# Altium schematic sheet DVC1124.SchDoc
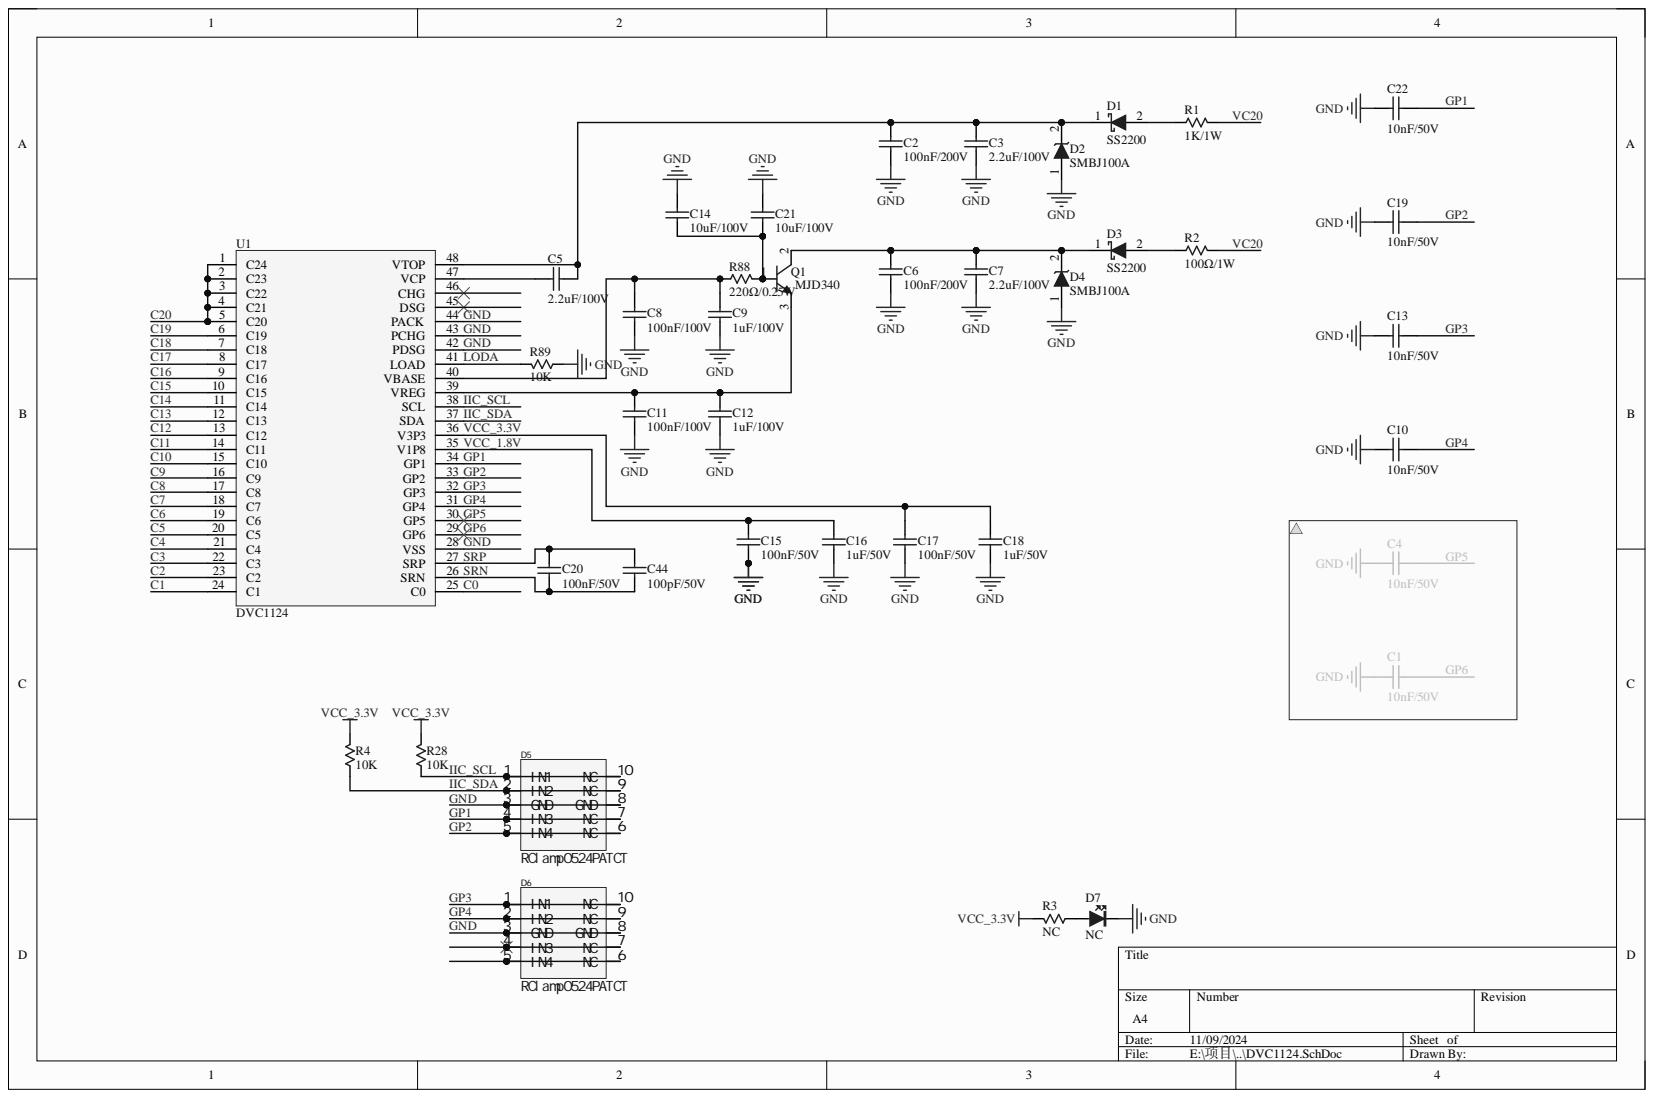
[diagram p0: DVC1124.SchDoc]

## Parts
C2  100nF/200V  [0805C]
C3  2.2uF/100V  [0805C]
C5  2.2uF/100V  [0805C]
C6  100nF/200V  [0805C]
C7  2.2uF/100V  [0805C]
C8  100nF/100V  [0805C]
C9  1uF/100V  [0805C]
C10  10nF/50V  [0603_C]
C11  100nF/100V  [0805C]
C12  1uF/100V  [0805C]
C13  10nF/50V  [0603_C]
C14  10uF/100V  [C1210]
C15  100nF/50V  [0603_C]
C16  1uF/50V  [0603_C]
C17  100nF/50V  [0603_C]
C18  1uF/50V  [0603_C]
C19  10nF/50V  [0603_C]
C20  100nF/50V  [0603_C]
C21  10uF/100V  [C1210]
C22  10nF/50V  [0603_C]
C44  100pF/50V  [0603_C]
D1  SS2200  [SMA/DO-214AC]
D2  SMBJ100A  [SMB/DO-214AA]
D3  SS2200  [SMA/DO-214AC]
D4  SMBJ100A  [SMB/DO-214AA]
D5  RClamp0524PATCT  [UFDFN-10]
D6  RClamp0524PATCT  [UFDFN-10]
D7  NC  [LED-0603G]
Q1  MJD340  [TO252]
R1  1K/1W  [2512_R]
R2  100Ω/1W  [R1210]
R3  NC  [0603_R]
R4  10K  [0603_R]
R28  10K  [0603_R]
R88  220Ω/0.25W  [0603_R]
R89  10K  [0603_R]
U1  DVC1124  [LQFP48 7X7]

## Nets
C0: U1.25(C0)
C1: U1.24(C1)
C2: U1.23(C2)
C3: U1.22(C3)
C4: U1.21(C4)
C5: U1.20(C5)
C6: U1.19(C6)
C7: U1.18(C7)
C8: U1.17(C8)
C9: U1.16(C9)
C10: U1.15(C10)
C11: U1.14(C11)
C12: U1.13(C12)
C13: U1.12(C13)
C14: U1.11(C14)
C15: U1.10(C15)
C16: U1.9(C16)
C17: U1.8(C17)
C18: U1.7(C18)
C19: U1.6(C19)
C20: U1.1(C24) U1.2(C23) U1.3(C22) U1.4(C21) U1.5(C20)
GND: C2.2 C3.2 C6.2 C7.2 C8.2 C9.2 C10.2 C11.2 C12.2 C13.2 C14.2 C15.2 C16.2 C17.2 C18.2 C19.2 C21.2 C22.2 D2.1 D4.1 D5.3(GND) D5.8(GND) D6.3(GND) D6.8(GND) D7.K R89.2 U1.28(VSS) U1.42(PDSG) U1.43(PCHG) U1.44(PACK)
GP1: C22.1 D5.4(IN3) D5.7(NC) U1.34(GP1)
GP2: C19.1 D5.5(IN4) D5.6(NC) U1.33(GP2)
GP3: C13.1 D6.1(IN1) D6.10(NC) U1.32(GP3)
GP4: C10.1 D6.2(IN2) D6.9(NC) U1.31(GP4)
GP5: U1.30(GP5)
GP6: U1.29(GP6)
IIC_SCL: D5.1(IN1) D5.10(NC) R28.2 U1.38(SCL)
IIC_SDA: D5.2(IN2) D5.9(NC) R4.2 U1.37(SDA)
LODA: R89.1 U1.41(LOAD)
SRN: C20.2 C44.2 U1.26(SRN)
SRP: C20.1 C44.1 U1.27(SRP)
VC20: R1.1 R2.1
VCC_1.8V: C15.1 C16.1 U1.35(V1P8)
VCC_3.3V: C17.1 C18.1 R3.1 R4.1 R28.1 U1.36(V3P3)
NetC2_1: C2.1 C3.1 C5.1 D1.1 D2.2 U1.48(VTOP)
NetC5_2: C5.2 U1.47(VCP)
NetC6_1: C6.1 C7.1 D3.1 D4.2 Q1.2
NetC8_1: C8.1 C9.1 R88.2 U1.40(VBASE)
NetC11_1: C11.1 C12.1 Q1.3 U1.39(VREG)
NetC14_1: C14.1 C21.1 Q1.1 R88.1
NetD1_2: D1.2 R1.2
NetD3_2: D3.2 R2.2
NetD6_4: D6.4(IN3) D6.7(NC)
NetD6_5: D6.5(IN4) D6.6(NC)
NetD7_A: D7.A R3.2

Not connected: U1 45(DSG) 46(CHG)

## Library data of the parts
C2 C3 C5-C22 C44 = Cap: Capacitor; Component Kind: Standard; LatestRevisionDate: 17-Jul-2002; LatestRevisionNote: Re-released for DXP Platform.; PackageReference: RAD-0.3; Published: 8-Jun-2000; Signal Integrity: Cap; Simulation: CAP; Library Name: UP-ROBOT Devices.SchLib; Publisher: Altium Limited
  C2 C6: Value: 100nF/200V
  C3 C5 C7: Value: 2.2uF/100V
  C8 C11: Value: 100nF/100V
  C9 C12: Value: 1uF/100V
  C10 C13 C19 C22: Value: 10nF/50V
  C14 C21: Value: 10uF/100V
  C15 C17 C20: Value: 100nF/50V
  C16 C18: Value: 1uF/50V
  C44: Value: 100pF/50V
D5 D6 = RClamp0524PATCT: Manufacturer: SEMTECH; Add into BOM: yes; Breakdown Voltage (VBR): 6V; Clamping Voltage@Ipp: 15V; Device: RClamp0524PATCT_C40960; Junction Capacitance(Cj)@1MHz: 0.8pF@1MHz; Number of Lines: 4; Peak Pulse Power(Ppp)@8/20us: 150W; Reverse Stand-Off Voltage (Vrwm): 5V; Component Kind: Standard; Convert to PCB: yes; Datasheet: https://atta.szlcsc.com/upload/public/pdf/source/20150124/1457707260739.pdf; JLCPCB Part Class: Extended Part; LCSC Part Name: RClamp0524PATCT; Library Name: DVC1124_20S.SchLib; Manufacturer Part: RClamp0524PATCT; Name: RClamp0524PATCT; Operating Temperature: -55℃~+125℃@(Tj); Supplier: LCSC; Supplier Footprint: SLP2510-8; Supplier Part: C40960; Symbol: RClamp0524PATCT
D7 = LED: Component Kind: Standard; Library Name: UP-ROBOT Devices.SchLib
Q1 = FCX495TA: Component Kind: Standard; Library Name: DVC1124_20S.SchLib
R1-R4 R28 R88 R89 = Res: Resistor; Component Kind: Standard; LatestRevisionDate: 17-Jul-2002; LatestRevisionNote: Re-released for DXP Platform.; PackageReference: AXIAL-0.3; Published: 8-Jun-2000; Signal Integrity: Res; Simulation: RESISTOR; Library Name: UP-ROBOT Devices.SchLib; Publisher: Altium Limited
  R1: Value: 1K/1W
  R2: Value: 100Ω/1W
  R3: Value: NC
  R4 R28 R89: Value: 10K
  R88: Value: 220Ω/0.25W
D1 D3 = SS2200: Component Kind: Standard; Library Name: DVC1124_20S.SchLib
D2 D4 = SMBJ100A: Component Kind: Standard; Library Name: DVC1124_20S.SchLib
U1 = DVC1124: Component Kind: Standard; Library Name: DVC1124_20S.SchLib
